# Altium schematic sheet blood_bar_board.SchDoc
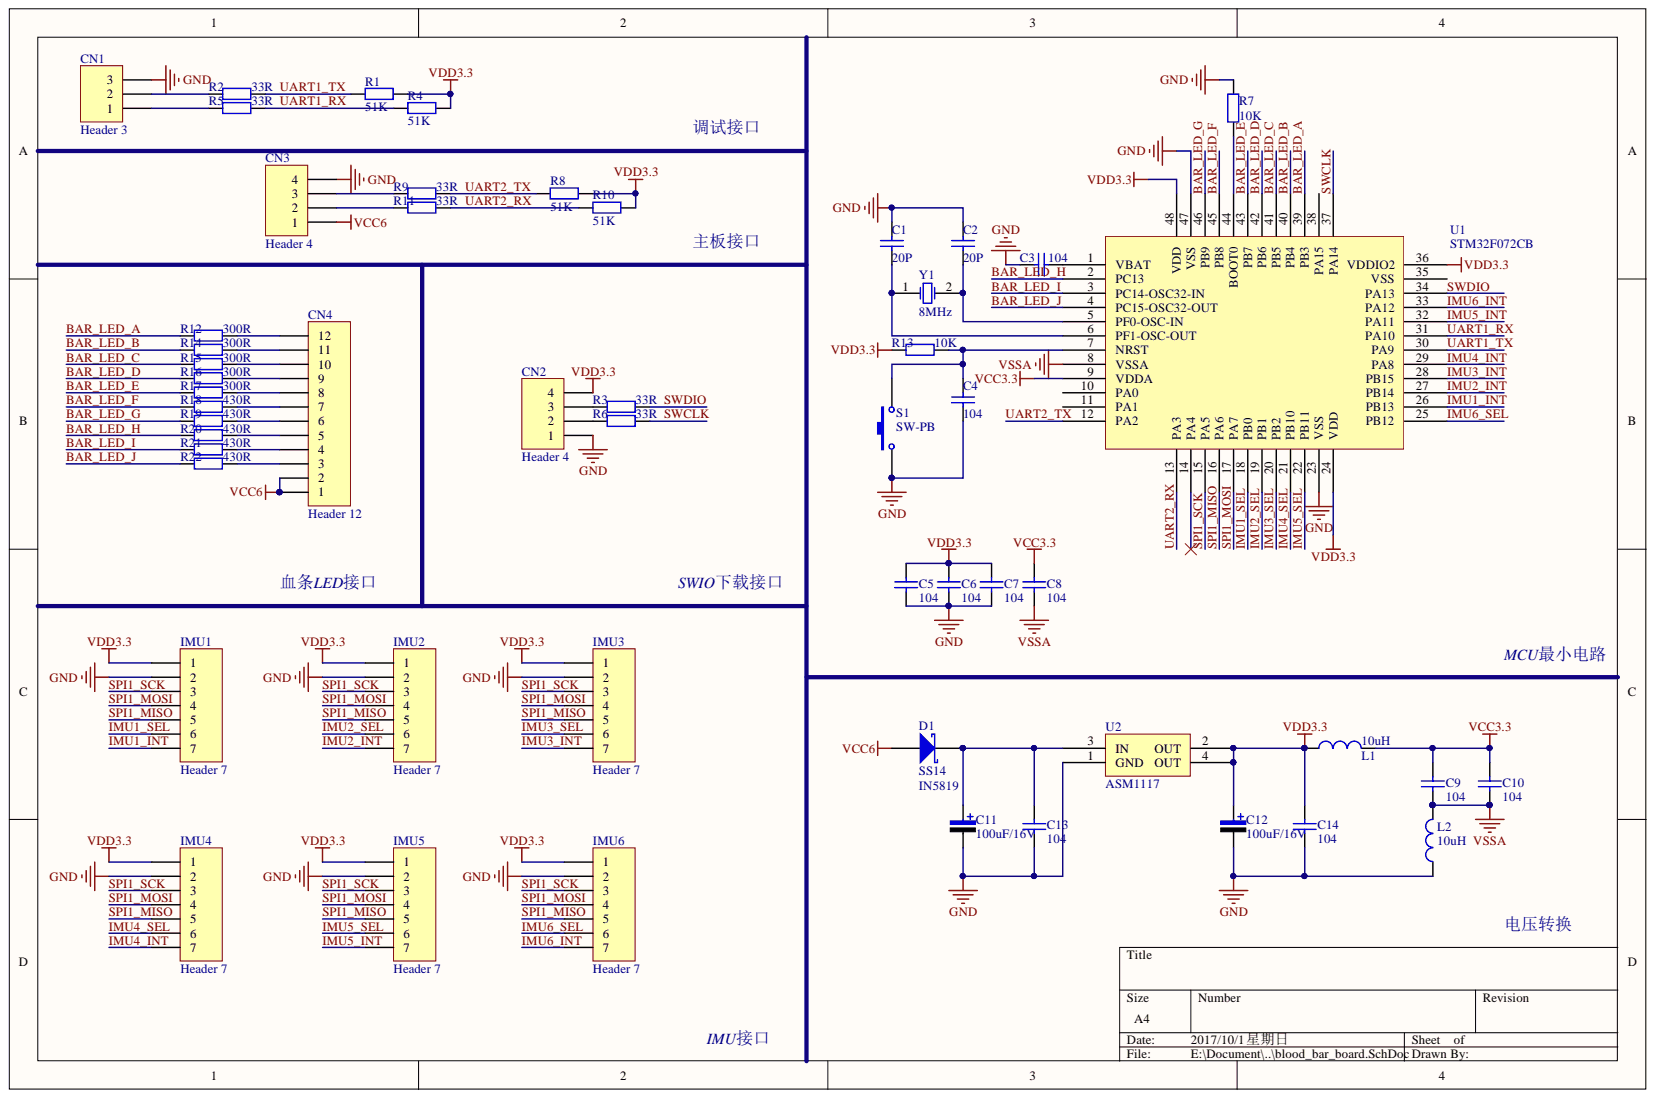
[diagram p0: blood_bar_board.SchDoc]

## Parts
C1  value 20P  [C-0603]  (hidden comment: 104,0603)
C2  value 20P  [C-0603]  (hidden comment: 104,0603)
C3  value 104  [C-0603]  (hidden comment: 104,0603)
C4  value 104  [C-0603]  (hidden comment: 104,0603)
C5  value 104  [C-0603]  (hidden comment: 104,0603)
C6  value 104  [C-0603]  (hidden comment: 104,0603)
C7  value 104  [C-0603]  (hidden comment: 104,0603)
C8  value 104  [C-0603]  (hidden comment: 104,0603)
C9  value 104  [C-0603]  (hidden comment: 104,0603)
C10  value 104  [C-0603]  (hidden comment: 104,0603)
C11  value 100uF/16V  [CAP-3528]  (hidden comment: Cap-Pol)
C12  value 100uF/16V  [CAP-3528]  (hidden comment: Cap-Pol)
C13  value 104  [C-0603]  (hidden comment: 104,0603)
C14  value 104  [C-0603]  (hidden comment: 104,0603)
CN1  Header 3  [HDR3X1-2.54]
CN2  Header 4  [HDR4X1-2.54]
CN3  Header 4  [SH1.0-4P-90S]
CN4  Header 12  [FPC0.5-12P-A]
D1  IN5819  Type: SS14  [D-SMA]
IMU1  Header 7  [SH1.0-7P-S]
IMU2  Header 7  [SH1.0-7P-S]
IMU3  Header 7  [SH1.0-7P-S]
IMU4  Header 7  [SH1.0-7P-S]
IMU5  Header 7  [SH1.0-7P-S]
IMU6  Header 7  [SH1.0-7P-S]
L1  value 10uH  [0603-1]  (hidden comment: Inductor)
L2  value 10uH  [0603-1]  (hidden comment: Inductor)
R1  value 51K  [0603-1]  (hidden comment: Res1)
R2  value 33R  [0603-1]  (hidden comment: Res1)
R3  value 33R  [0603-1]  (hidden comment: Res1)
R4  value 51K  [0603-1]  (hidden comment: Res1)
R5  value 33R  [0603-1]  (hidden comment: Res1)
R6  value 33R  [0603-1]  (hidden comment: Res1)
R7  value 10K  [0603-1]  (hidden comment: Res1)
R8  value 51K  [0603-1]  (hidden comment: Res1)
R9  value 33R  [0603-1]  (hidden comment: Res1)
R10  value 51K  [0603-1]  (hidden comment: Res1)
R11  value 33R  [0603-1]  (hidden comment: Res1)
R12  value 300R  [0603-1]  (hidden comment: Res1)
R13  value 10K  [0603-1]  (hidden comment: Res1)
R14  value 300R  [0603-1]  (hidden comment: Res1)
R15  value 300R  [0603-1]  (hidden comment: Res1)
R16  value 300R  [0603-1]  (hidden comment: Res1)
R17  value 300R  [0603-1]  (hidden comment: Res1)
R18  value 430R  [0603-1]  (hidden comment: Res1)
R19  value 430R  [0603-1]  (hidden comment: Res1)
R20  value 430R  [0603-1]  (hidden comment: Res1)
R21  value 430R  [0603-1]  (hidden comment: Res1)
R22  value 430R  [0603-1]  (hidden comment: Res1)
S1  SW-PB  [SW_PB2_A1]
U1  STM32F072CB  [STM32F072CB-LQFP48]
U2  ASM1117  [SOT223]
Y1  8MHz  [5032]

## Nets
GND: C1.2 C2.2 C3.2 C4.1 C5.1 C6.1 C7.1 C11.2 C12.2 C13.1 C14.1 CN1.3 CN2.1 CN3.4 IMU1.2 IMU2.2 IMU3.2 IMU4.2 IMU5.2 IMU6.2 L2.1 R7.1 S1.1 U1.23(VSS) U1.47(VSS) U2.1(GND)
VCC3.3: C8.2 C9.2 C10.2 L1.2 U1.9(VDDA)
VCC6: CN3.1 CN4.1 CN4.2 D1.1
VDD3.3: C5.2 C6.2 C7.2 C12.1 C14.2 CN2.4 IMU1.1 IMU2.1 IMU3.1 IMU4.1 IMU5.1 IMU6.1 L1.1 R1.2 R4.2 R8.1 R10.2 R13.1 U1.24(VDD) U1.36(VDDIO2) U1.48(VDD) U2.2(OUT) U2.4(OUT)
VSSA: C8.1 C9.1 C10.1 L2.2 U1.8(VSSA)
BAR_LED_A: R12.2 U1.39(PB3)
BAR_LED_B: R14.2 U1.40(PB4)
BAR_LED_C: R15.2 U1.41(PB5)
BAR_LED_D: R16.2 U1.42(PB6)
BAR_LED_E: R17.2 U1.43(PB7)
BAR_LED_F: R18.2 U1.45(PB8)
BAR_LED_G: R19.2 U1.46(PB9)
BAR_LED_H: R20.2 U1.2(PC13)
BAR_LED_I: R21.2 U1.3(PC14-OSC32-IN)
BAR_LED_J: R22.2 U1.4(PC15-OSC32-OUT)
IMU1_INT: IMU1.7 U1.26(PB13)
IMU1_SEL: IMU1.6 U1.18(PB0)
IMU2_INT: IMU2.7 U1.27(PB14)
IMU2_SEL: IMU2.6 U1.19(PB1)
IMU3_INT: IMU3.7 U1.28(PB15)
IMU3_SEL: IMU3.6 U1.20(PB2)
IMU4_INT: IMU4.7 U1.29(PA8)
IMU4_SEL: IMU4.6 U1.21(PB10)
IMU5_INT: IMU5.7 U1.32(PA11)
IMU5_SEL: IMU5.6 U1.22(PB11)
IMU6_INT: IMU6.7 U1.33(PA12)
IMU6_SEL: IMU6.6 U1.25(PB12)
SPI1_MISO: IMU1.5 IMU2.5 IMU3.5 IMU4.5 IMU5.5 IMU6.5 U1.16(PA6)
SPI1_MOSI: IMU1.4 IMU2.4 IMU3.4 IMU4.4 IMU5.4 IMU6.4 U1.17(PA7)
SPI1_SCK: IMU1.3 IMU2.3 IMU3.3 IMU4.3 IMU5.3 IMU6.3 U1.15(PA5)
SWCLK: R6.1 U1.37(PA14)
SWDIO: R3.1 U1.34(PA13)
UART1_RX: R4.1 R5.1 U1.31(PA10)
UART1_TX: R1.1 R2.1 U1.30(PA9)
UART2_RX: R10.1 R11.1 U1.13(PA3)
UART2_TX: R8.2 R9.1 U1.12(PA2)
NetC1_1: C1.1 U1.6(PF1-OSC-OUT) Y1.1
NetC2_1: C2.1 U1.5(PF0-OSC-IN) Y1.2
NetC3_1: C3.1 U1.1(VBAT)
NetC4_2: C4.2 R13.2 S1.2 U1.7(NRST)
NetC11_1: C11.1 C13.2 D1.2 U2.3(IN)
NetCN1_1: CN1.1 R5.2
NetCN1_2: CN1.2 R2.2
NetCN2_2: CN2.2 R6.2
NetCN2_3: CN2.3 R3.2
NetCN3_2: CN3.2 R11.2
NetCN3_3: CN3.3 R9.2
NetCN4_3: CN4.3 R22.1
NetCN4_4: CN4.4 R21.1
NetCN4_5: CN4.5 R20.1
NetCN4_6: CN4.6 R19.1
NetCN4_7: CN4.7 R18.1
NetCN4_8: CN4.8 R17.1
NetCN4_9: CN4.9 R16.1
NetCN4_10: CN4.10 R15.1
NetCN4_11: CN4.11 R14.1
NetCN4_12: CN4.12 R12.1
NetR7_2: R7.2 U1.44(BOOT0)

Not connected: U1 10(PA0) 11(PA1) 14(PA4) 35(VSS) 38(PA15)

## Library data of the parts
C1-C10 C13 C14 = Cap: Capacitor; Component Kind: Standard; LatestRevisionDate: 17-Jul-2002; LatestRevisionNote: Re-released for DXP Platform.; Published: 8-Jun-2000; Library Name: STM32F103VET6_VCT6mini最小系统-原理图库.SCHLIB; Publisher: Altium Limited
C11 C12 = Cap-Pol-A: 钽电容; Component Kind: Standard; LatestRevisionDate: 17-Jul-2002; LatestRevisionNote: Re-released for DXP Platform.; PackageReference: RB7.6-15; Published: 8-Jun-2000; Library Name: Fszhang.IntLib; Publisher: Altium Limited
CN1 = Header 3: Header, 3-Pin; Component Kind: Standard; LatestRevisionDate: 17-Jul-2002; LatestRevisionNote: Re-released for DXP Platform.; Library Name: Miscellaneous Connectors.IntLib; Publisher: Altium Limited
CN2 CN3 = Header 4: Header, 4-Pin; Component Kind: Standard; LatestRevisionDate: 17-Jul-2002; LatestRevisionNote: Re-released for DXP Platform.; Library Name: Miscellaneous Connectors.IntLib; Publisher: Altium Limited
CN4 = Header 12: Header, 12-Pin; Component Kind: Standard; LatestRevisionDate: 17-Jul-2002; LatestRevisionNote: Re-released for DXP Platform.; Library Name: Miscellaneous Connectors.IntLib; Publisher: Altium Limited
D1 = Diode-Schottky: 肖特基二极管; Code_JEDEC: DO-214-AA; Component Kind: Standard; LatestRevisionDate: 17-Jul-2002; LatestRevisionNote: Re-released for DXP Platform.; PackageReference: SMB; Published: 8-Jun-2000; Library Name: Fszhang.IntLib; Publisher: Altium Limited
IMU1-IMU6 = Header 7: Header, 7-Pin; Component Kind: Standard; LatestRevisionDate: 17-Jul-2002; LatestRevisionNote: Re-released for DXP Platform.; Library Name: Miscellaneous Connectors.IntLib; Publisher: Altium Limited
L1 L2 = Inductor: 电感; Code_IEC: 1005; Code_JEITA: 0402; Component Kind: Standard; LatestRevisionDate: 17-Jul-2002; LatestRevisionNote: Re-released for DXP Platform.; PackageReference: 0402-A; Published: 8-Jun-2000; Library Name: Fszhang.IntLib; Publisher: Altium Limited
R1-R22 = Res1: 贴片电阻; Component Kind: Standard; LatestRevisionDate: 17-Jul-2002; LatestRevisionNote: Re-released for DXP Platform.; PackageReference: AXIAL-0.4; Published: 8-Jun-2000; Library Name: Fszhang.IntLib; Publisher: Altium Limited
S1 = SW-PB: 微动开关; Component Kind: Standard; LatestRevisionDate: 17-Jul-2002; LatestRevisionNote: Re-released for DXP Platform.; PackageReference: SPST-2; Published: 24-Mar-1999; Library Name: Fszhang.IntLib; Publisher: Altium Limited
U2 = ASM1117: 3.3V稳压芯片; Component Kind: Standard; Library Name: Fszhang.IntLib
Y1 = XTAL: Crystal Oscillator; Component Kind: Standard; LatestRevisionDate: 17-Jul-2002; LatestRevisionNote: Re-released for DXP Platform.; Published: 8-Jun-2000; Library Name: STM32F103VET6_VCT6mini最小系统-原理图库.SCHLIB; Publisher: Altium Limited
U1 = STM32F072CB: Component Kind: Standard; Description: STM32F072CB; Library Name: STM32F072CB.SchLib
